# Altium schematic sheet Core.SchDoc(Core)
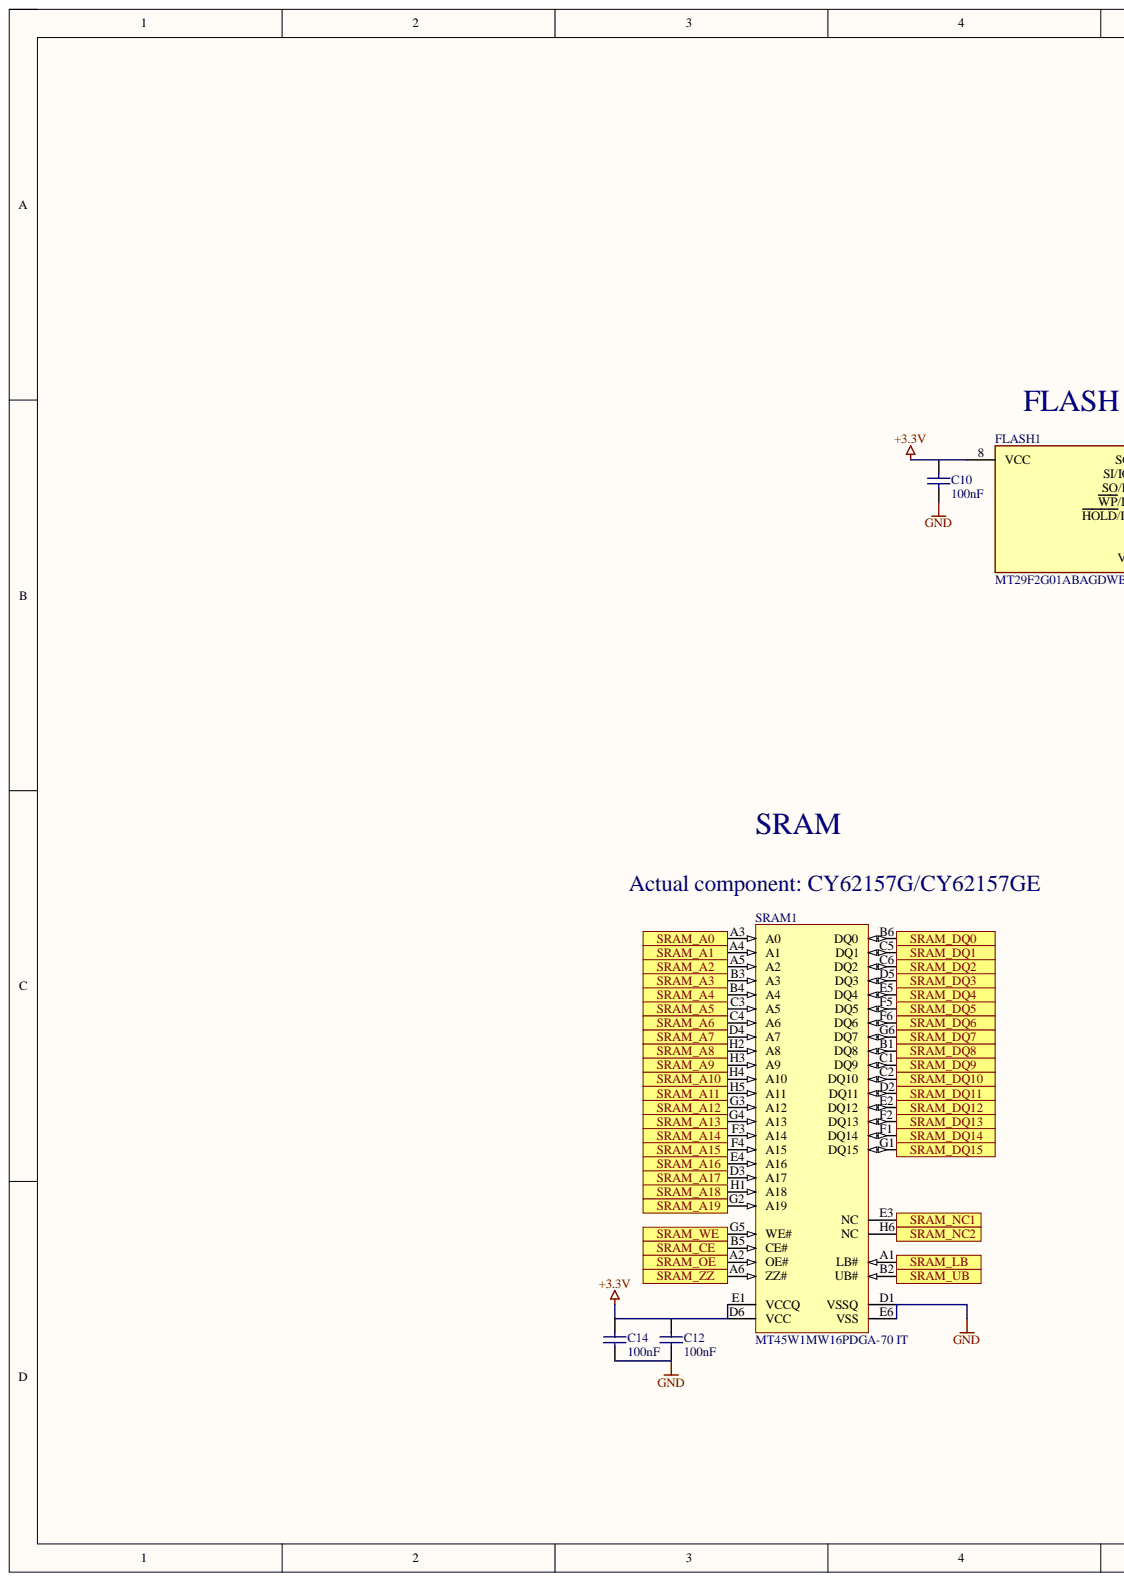
[diagram p0: Core.SchDoc, left]
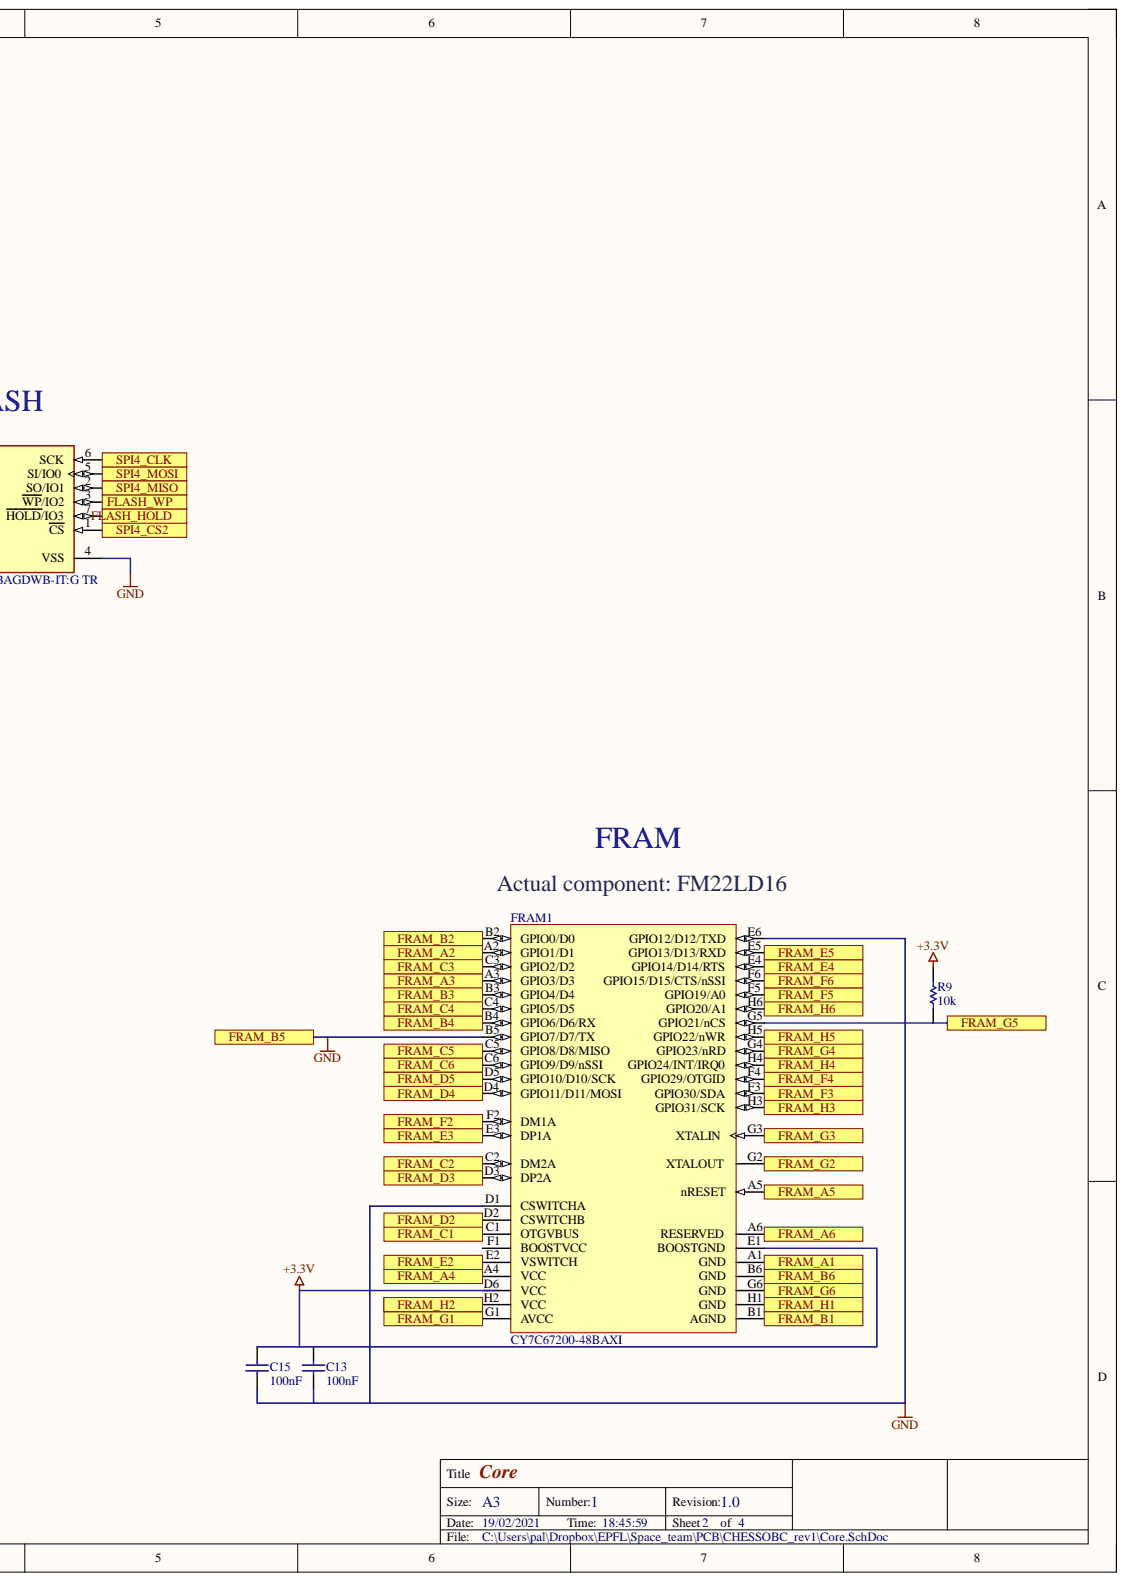
[diagram p1: Core.SchDoc, right]

## Parts
C10  100nF  [CAPC1005X60X30ML05T10]
C12  100nF  [CAPC1005X60X30ML05T10]
C13  100nF  [CAPC1005X60X30ML05T10]
C14  100nF  [CAPC1005X60X30ML05T10]
C15  100nF  [CAPC1005X60X30ML05T10]
FLASH1  MT29F2G01ABAGDWB-IT:G TR  [FP-WB-IPC_C]
FRAM1  CY7C67200-48BAXI  [CYP-BAX48]
R9  10k  [RESC1005X40X25LL05T10]
SRAM1  MT45W1MW16PDGA-70 IT  [MICT-GA-48]

## Ports
FLASH_HOLD, FLASH_WP, FRAM_A1, FRAM_A2, FRAM_A3, FRAM_A4, FRAM_A5, FRAM_A6, FRAM_B1, FRAM_B2, FRAM_B3, FRAM_B4, FRAM_B5, FRAM_B6, FRAM_C1, FRAM_C2, FRAM_C3, FRAM_C4, FRAM_C5, FRAM_C6, FRAM_D2, FRAM_D3, FRAM_D4, FRAM_D5, FRAM_E2, FRAM_E3, FRAM_E4, FRAM_E5, FRAM_F2, FRAM_F3, FRAM_F4, FRAM_F5, FRAM_F6, FRAM_G1, FRAM_G2, FRAM_G3, FRAM_G4, FRAM_G5, FRAM_G6, FRAM_H1, FRAM_H2, FRAM_H3, FRAM_H4, FRAM_H5, FRAM_H6, SPI4_CLK, SPI4_CS2, SPI4_MISO, SPI4_MOSI, SRAM_A0, SRAM_A1, SRAM_A2, SRAM_A3, SRAM_A4, SRAM_A5, SRAM_A6, SRAM_A7, SRAM_A8, SRAM_A9, SRAM_A10, SRAM_A11, SRAM_A12, SRAM_A13, SRAM_A14, SRAM_A15, SRAM_A16, SRAM_A17, SRAM_A18, SRAM_A19, SRAM_CE, SRAM_DQ0, SRAM_DQ1, SRAM_DQ2, SRAM_DQ3, SRAM_DQ4, SRAM_DQ5, SRAM_DQ6, SRAM_DQ7, SRAM_DQ8, SRAM_DQ9, SRAM_DQ10, SRAM_DQ11, SRAM_DQ12, SRAM_DQ13, SRAM_DQ14, SRAM_DQ15, SRAM_LB, SRAM_NC1, SRAM_NC2, SRAM_OE, SRAM_UB, SRAM_WE, SRAM_ZZ

## Nets
+3.3V: C10.2 C12.2 C13.2 C14.2 C15.2 FLASH1.8(VCC) FRAM1.D6(VCC) FRAM1.E1(BOOSTGND) R9.2 SRAM1.D6(VCC) SRAM1.E1(VCCQ)
FLASH_HOLD: FLASH1.7(HOLD/IO3) ; port FLASH_HOLD
FLASH_WP: FLASH1.3(WP/IO2) ; port FLASH_WP
FRAM_A1: FRAM1.A1(GND) ; port FRAM_A1
FRAM_A2: FRAM1.A2(GPIO1/D1) ; port FRAM_A2
FRAM_A3: FRAM1.A3(GPIO3/D3) ; port FRAM_A3
FRAM_A4: FRAM1.A4(VCC) ; port FRAM_A4
FRAM_A5: FRAM1.A5(nRESET) ; port FRAM_A5
FRAM_A6: FRAM1.A6(RESERVED) ; port FRAM_A6
FRAM_B1: FRAM1.B1(AGND) ; port FRAM_B1
FRAM_B2: FRAM1.B2(GPIO0/D0) ; port FRAM_B2
FRAM_B3: FRAM1.B3(GPIO4/D4) ; port FRAM_B3
FRAM_B4: FRAM1.B4(GPIO6/D6/RX) ; port FRAM_B4
FRAM_B6: FRAM1.B6(GND) ; port FRAM_B6
FRAM_C1: FRAM1.C1(OTGVBUS) ; port FRAM_C1
FRAM_C2: FRAM1.C2(DM2A) ; port FRAM_C2
FRAM_C3: FRAM1.C3(GPIO2/D2) ; port FRAM_C3
FRAM_C4: FRAM1.C4(GPIO5/D5) ; port FRAM_C4
FRAM_C5: FRAM1.C5(GPIO8/D8/MISO) ; port FRAM_C5
FRAM_C6: FRAM1.C6(GPIO9/D9/nSSI) ; port FRAM_C6
FRAM_D2: FRAM1.D2(CSWITCHB) ; port FRAM_D2
FRAM_D3: FRAM1.D3(DP2A) ; port FRAM_D3
FRAM_D4: FRAM1.D4(GPIO11/D11/MOSI) ; port FRAM_D4
FRAM_D5: FRAM1.D5(GPIO10/D10/SCK) ; port FRAM_D5
FRAM_E2: FRAM1.E2(VSWITCH) ; port FRAM_E2
FRAM_E3: FRAM1.E3(DP1A) ; port FRAM_E3
FRAM_E4: FRAM1.E4(GPIO14/D14/RTS) ; port FRAM_E4
FRAM_E5: FRAM1.E5(GPIO13/D13/RXD) ; port FRAM_E5
FRAM_F2: FRAM1.F2(DM1A) ; port FRAM_F2
FRAM_F3: FRAM1.F3(GPIO30/SDA) ; port FRAM_F3
FRAM_F4: FRAM1.F4(GPIO29/OTGID) ; port FRAM_F4
FRAM_F5: FRAM1.F5(GPIO19/A0) ; port FRAM_F5
FRAM_F6: FRAM1.F6(GPIO15/D15/CTS/nSSI) ; port FRAM_F6
FRAM_G1: FRAM1.G1(AVCC) ; port FRAM_G1
FRAM_G2: FRAM1.G2(XTALOUT) ; port FRAM_G2
FRAM_G3: FRAM1.G3(XTALIN) ; port FRAM_G3
FRAM_G4: FRAM1.G4(GPIO23/nRD) ; port FRAM_G4
FRAM_G5: FRAM1.G5(GPIO21/nCS) R9.1 ; port FRAM_G5
FRAM_G6: FRAM1.G6(GND) ; port FRAM_G6
FRAM_H1: FRAM1.H1(GND) ; port FRAM_H1
FRAM_H2: FRAM1.H2(VCC) ; port FRAM_H2
FRAM_H3: FRAM1.H3(GPIO31/SCK) ; port FRAM_H3
FRAM_H4: FRAM1.H4(GPIO24/INT/IRQ0) ; port FRAM_H4
FRAM_H5: FRAM1.H5(GPIO22/nWR) ; port FRAM_H5
FRAM_H6: FRAM1.H6(GPIO20/A1) ; port FRAM_H6
GND: C10.1 C12.1 C13.1 C14.1 C15.1 FLASH1.4(VSS) FRAM1.B5(GPIO7/D7/TX) FRAM1.D1(CSWITCHA) FRAM1.E6(GPIO12/D12/TXD) SRAM1.D1(VSSQ) SRAM1.E6(VSS) ; port FRAM_B5
SPI4_CLK: FLASH1.6(SCK) ; port SPI4_CLK
SPI4_CS2: FLASH1.1(CS) ; port SPI4_CS2
SPI4_MISO: FLASH1.2(SO/IO1) ; port SPI4_MISO
SPI4_MOSI: FLASH1.5(SI/IO0) ; port SPI4_MOSI
SRAM_A0: SRAM1.A3(A0) ; port SRAM_A0
SRAM_A1: SRAM1.A4(A1) ; port SRAM_A1
SRAM_A2: SRAM1.A5(A2) ; port SRAM_A2
SRAM_A3: SRAM1.B3(A3) ; port SRAM_A3
SRAM_A4: SRAM1.B4(A4) ; port SRAM_A4
SRAM_A5: SRAM1.C3(A5) ; port SRAM_A5
SRAM_A6: SRAM1.C4(A6) ; port SRAM_A6
SRAM_A7: SRAM1.D4(A7) ; port SRAM_A7
SRAM_A8: SRAM1.H2(A8) ; port SRAM_A8
SRAM_A9: SRAM1.H3(A9) ; port SRAM_A9
SRAM_A10: SRAM1.H4(A10) ; port SRAM_A10
SRAM_A11: SRAM1.H5(A11) ; port SRAM_A11
SRAM_A12: SRAM1.G3(A12) ; port SRAM_A12
SRAM_A13: SRAM1.G4(A13) ; port SRAM_A13
SRAM_A14: SRAM1.F3(A14) ; port SRAM_A14
SRAM_A15: SRAM1.F4(A15) ; port SRAM_A15
SRAM_A16: SRAM1.E4(A16) ; port SRAM_A16
SRAM_A17: SRAM1.D3(A17) ; port SRAM_A17
SRAM_A18: SRAM1.H1(A18) ; port SRAM_A18
SRAM_A19: SRAM1.G2(A19) ; port SRAM_A19
SRAM_CE: SRAM1.B5(CE#) ; port SRAM_CE
SRAM_DQ0: SRAM1.B6(DQ0) ; port SRAM_DQ0
SRAM_DQ1: SRAM1.C5(DQ1) ; port SRAM_DQ1
SRAM_DQ2: SRAM1.C6(DQ2) ; port SRAM_DQ2
SRAM_DQ3: SRAM1.D5(DQ3) ; port SRAM_DQ3
SRAM_DQ4: SRAM1.E5(DQ4) ; port SRAM_DQ4
SRAM_DQ5: SRAM1.F5(DQ5) ; port SRAM_DQ5
SRAM_DQ6: SRAM1.F6(DQ6) ; port SRAM_DQ6
SRAM_DQ7: SRAM1.G6(DQ7) ; port SRAM_DQ7
SRAM_DQ8: SRAM1.B1(DQ8) ; port SRAM_DQ8
SRAM_DQ9: SRAM1.C1(DQ9) ; port SRAM_DQ9
SRAM_DQ10: SRAM1.C2(DQ10) ; port SRAM_DQ10
SRAM_DQ11: SRAM1.D2(DQ11) ; port SRAM_DQ11
SRAM_DQ12: SRAM1.E2(DQ12) ; port SRAM_DQ12
SRAM_DQ13: SRAM1.F2(DQ13) ; port SRAM_DQ13
SRAM_DQ14: SRAM1.F1(DQ14) ; port SRAM_DQ14
SRAM_DQ15: SRAM1.G1(DQ15) ; port SRAM_DQ15
SRAM_LB: SRAM1.A1(LB#) ; port SRAM_LB
SRAM_NC1: SRAM1.E3(NC) ; port SRAM_NC1
SRAM_NC2: SRAM1.H6(NC) ; port SRAM_NC2
SRAM_OE: SRAM1.A2(OE#) ; port SRAM_OE
SRAM_UB: SRAM1.B2(UB#) ; port SRAM_UB
SRAM_WE: SRAM1.G5(WE#) ; port SRAM_WE
SRAM_ZZ: SRAM1.A6(ZZ#) ; port SRAM_ZZ

Not connected: FRAM1 F1(BOOSTVCC)

## Library data of the parts
C10 C12-C15 = CMP-2008-02046-1: Manufacturer: Kemet; Part Number: C0402C104K8PACTU; Component Kind: Standard; Library Name: Altium Content Vault
FLASH1 = CMP-14958-000013-1: IC FLASH 2G SPI 8UPDFN; Memory Size: 2Gb; Memory Type: Non-Volatile; Component Kind: Standard; Interface: SPI; Library Name: Altium Content Vault; Max Operating Temperature: 85°C; Min Operating Temperature: -40°C; Mount: Surface Mount; Number of Pins: 8; Packaging: Tape and Reel
FRAM1 = CMP-0967-00022-1: EZ-OTG(TM) Programmable USB On-The-Go Host / Peripheral Controller, 16 KB, 25 I/Os, 2.7 to 3.6 V, -40 to 85 degC, 48-Pin FBGA (BAX48), Tray; Manufacturer: Cypress; Max. Operating Voltage (V): 3.6; Memory Architecture: ROM/RAM; Memory Size (KB): 16; Min. Operating Voltage (V): 2.7; No. of I/Os: 25; Component Kind: Standard; ComponentLink1Description: Manufacturer URL; ComponentLink1URL: http://www.cypress.com/; ComponentLink2Description: Datasheet; ComponentLink2URL: http://www.cypress.com/?docID=45275; ComponentLink3Description: Package Specification; ComponentLink3URL: http://www.cypress.com/?docID=1012; DatasheetVersion: Rev. *J; Library Name: Altium Content Vault; Max. Operating Temp. (degreesC): 85; Min. Operating Temp. (degreesC): -40; Mounting Technology: Surface Mount; PackageDescription: 48-Ball Fine-Pitch Ball Grid Array, Body 7 x 7 mm, Pitch 0.75 mm; PackageReference: BAX48; PackageVersion: Rev. *F, 09/2005; Packing: Tray; PartNumber: CY7C67200-48BAXI; RoHS: Pb-Free
R9 = CMP-2002-07353-1: Manufacturer: Yageo / Phycomp; Part Number: RC0402FR-0710KL; Component Kind: Standard; Library Name: Altium Content Vault
SRAM1 = CMP-0356-00001-1: 16Mb PSRAM Celluar RAM, 1Mb x 16, 70ns, 1.7V - 3.3V, -40 to 85 degC, 48-Ball VFBGA, Pb-Free; Manufacturer: Micron; Access Time: 70nsÂ; Core Voltage: 1.7V-1.95VÂ; Density: 16MbÂ; I/O Voltage: 1.7V-3.3VÂ; Op. Temp.: -40C to +85CÂ; Read/Write Mode: Async/PageÂ; Component Kind: Standard; ComponentLink1Description: Manufacturer URL; ComponentLink1URL: http://www.micron.com/; ComponentLink2Description: Datasheet; ComponentLink2URL: http://www.micron.com/~/media/Documents/Products/Data%20Sheet/DRAM/Mobile%20DRAM/PSRAM/16mb_asyncpage_cr1_0_p23z.pdf; ComponentLink3Description: Package Specification; ComponentLink3URL: http://www.micron.com/~/media/Documents/Products/Data%20Sheet/DRAM/Mobile%20DRAM/PSRAM/16mb_asyncpage_cr1_0_p23z.pdf; DatasheetVersion: Rev. F; Depth: 1MbÂ; Library Name: Altium Content Vault; Mounting Technology: Surface Mount; Package: VFBGAÂ; PackageDescription: 48-Ball Very Thin Profile Fine-Pitch Ball Grid Array, 8 x 6 mm, Pitch 0.75 mm; PackageReference: MICT-GA-48; PackageVersion: Rev.F; Part Status: ProductionÂ; PartNumber: MT45W1MW16PDGA-70 IT; Pin Count: 48-ballÂ; RoHS: GreenÂ; Width: x16Â
